# Altium schematic sheet 01_power.SchDoc(01_power)
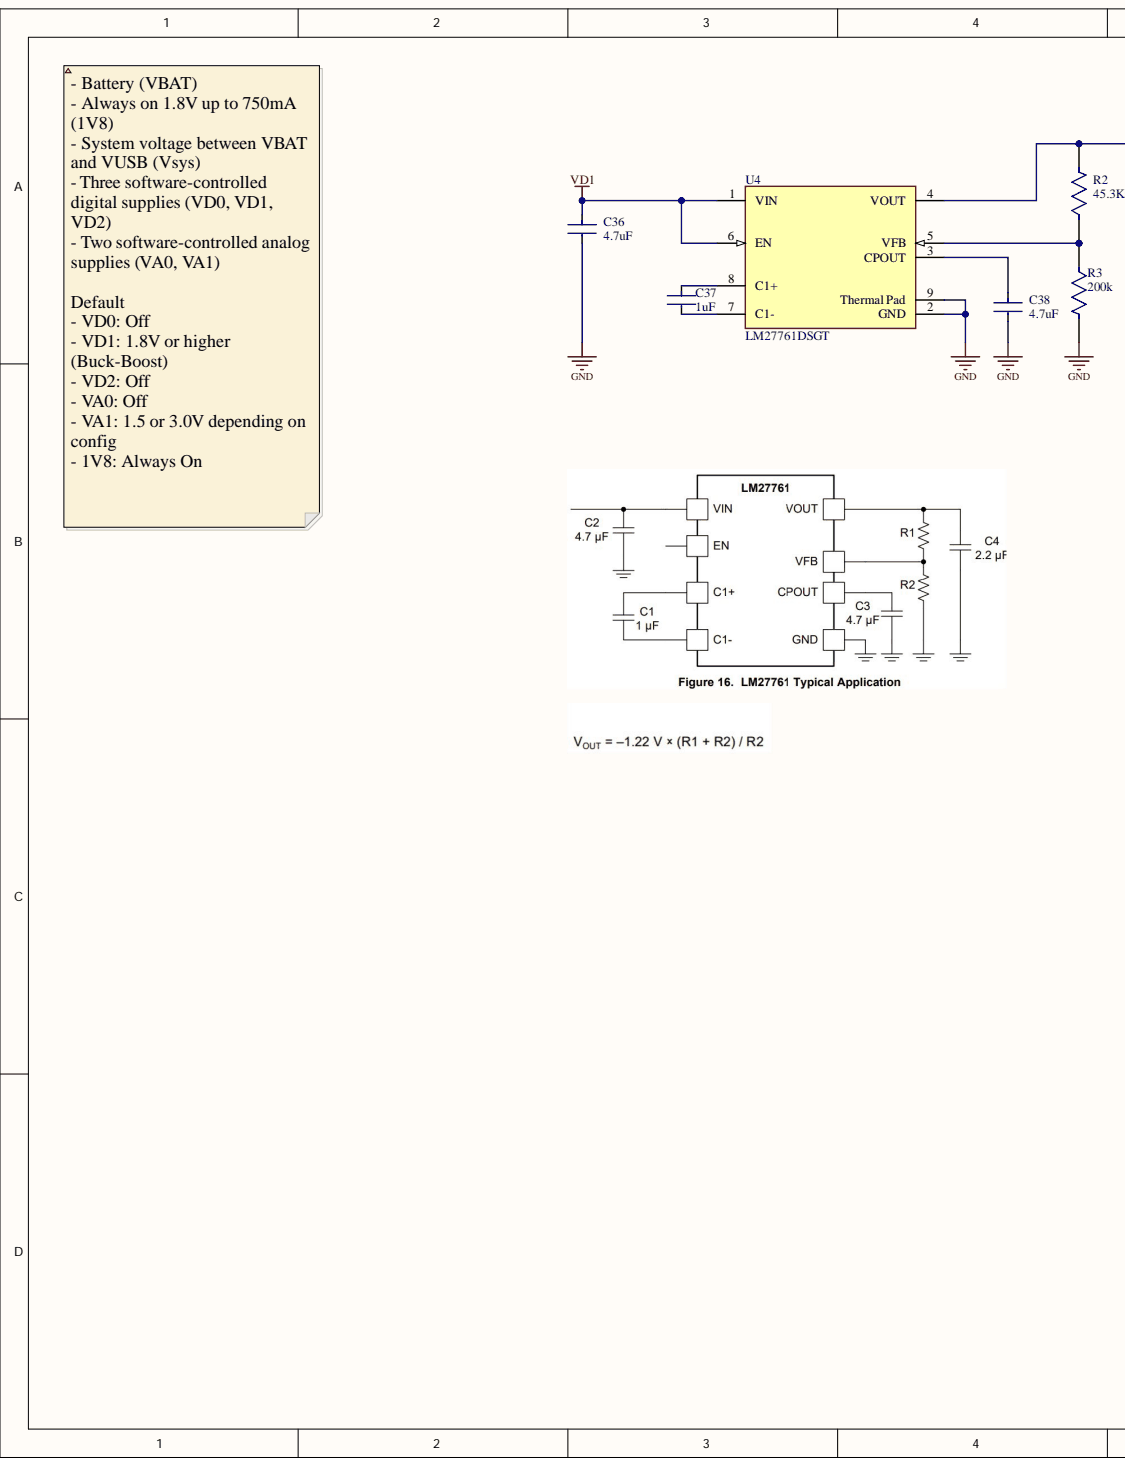
[diagram p0: 01_power.SchDoc, left]
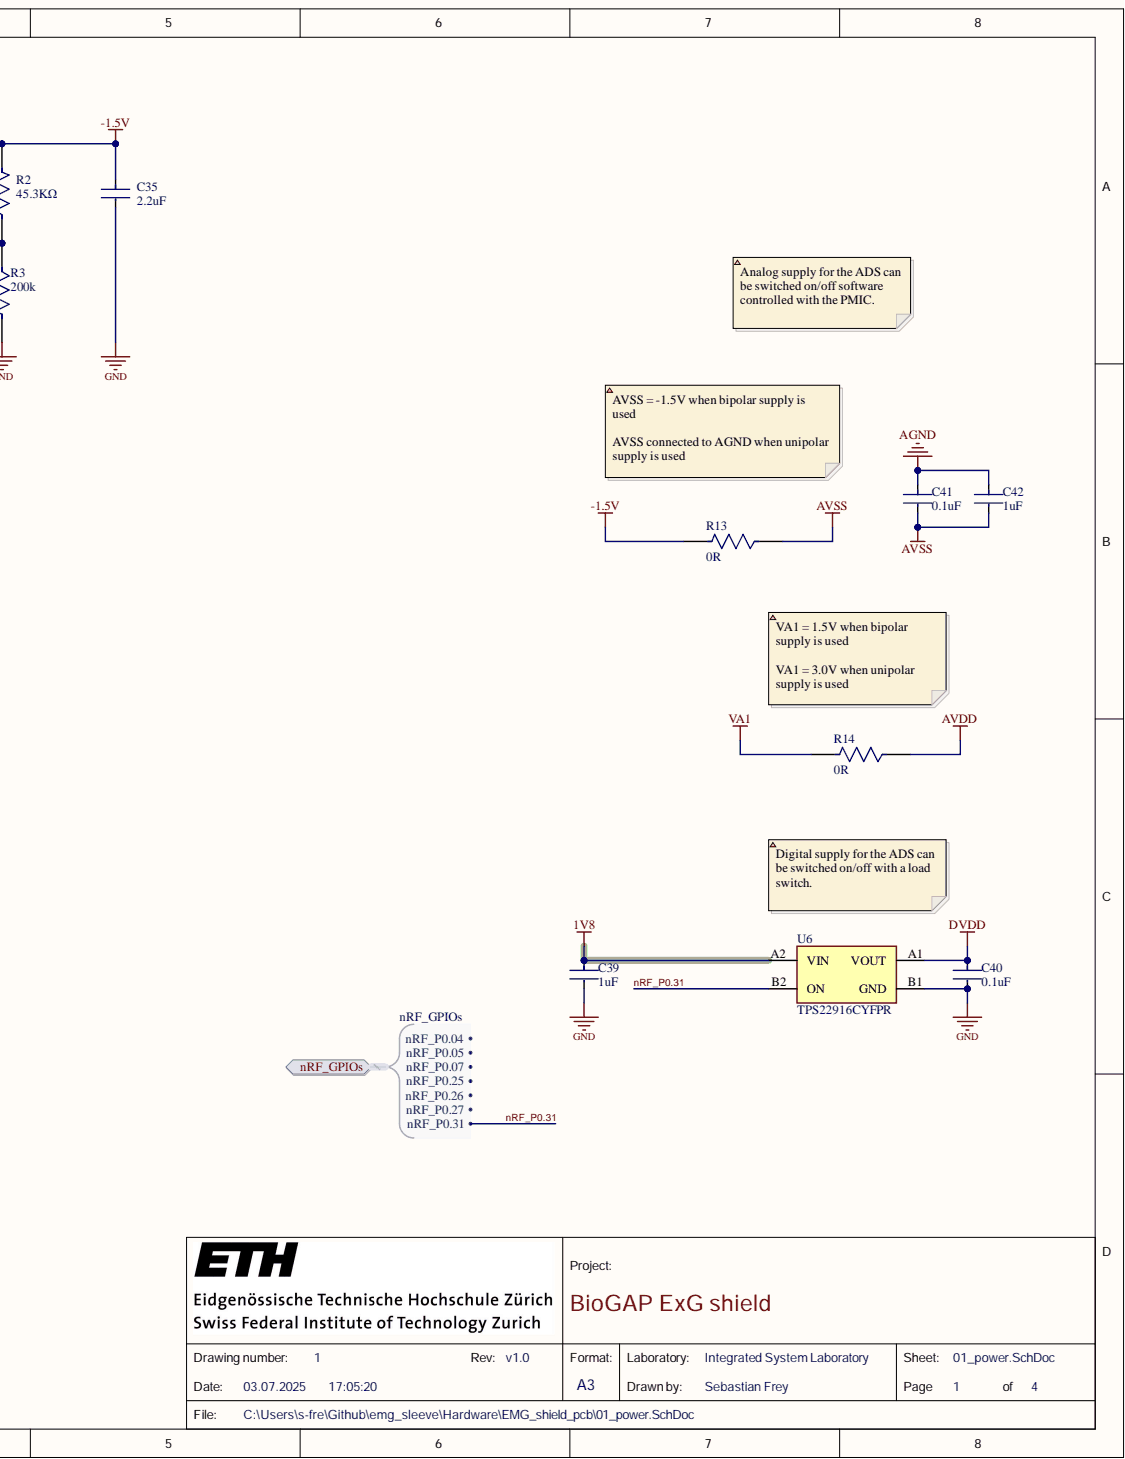
[diagram p1: 01_power.SchDoc, right]

## Parts
C35  value 2.2uF  Capacitance: 2.2uF  [FP-GRM155-0_15-IPC_B]  (hidden comment: GRM155Z71A225KE01D)
C36  value 4.7uF  Capacitance: 4.7uF  [FP-CL282-IPC_C]  (hidden comment: CL05A475MP5NRNC)
C37  value 1uF  Capacitance: 1uF  [FP-CL511-IPC_C]  (hidden comment: CL05A105KP5NNNC)
C38  value 4.7uF  Capacitance: 4.7uF  [FP-CL282-IPC_C]  (hidden comment: CL05A475MP5NRNC)
C39  value 1uF  Capacitance: 1uF  [FP-CL511-IPC_C]  (hidden comment: CL05A105KP5NNNC)
C40  value 0.1uF  Capacitance: 0.1uF  [FP-CL278-IPC_C]  (hidden comment: CL05A104KA5NNNC)
C41  value 0.1uF  Capacitance: 0.1uF  [FP-CL278-IPC_C]  (hidden comment: CL05A104KA5NNNC)
C42  value 1uF  Capacitance: 1uF  [FP-CL511-IPC_C]  (hidden comment: CL05A105KP5NNNC)
R2  value 45.3KΩ  Resistance: 45.3KΩ  [FP-RT0402-MFG]  (hidden comment: RT0402BRD0745K3L)
R3  value 200k  Resistance: 200k  [FP-RN73R1E-IPC_C]  (hidden comment: RN73R1ETTP2003B25)
R13  value 0R  Resistance: 0R  [FP-RC0402-0_4-IPC_A]  (hidden comment: RC0402FR-070RL)
R14  value 0R  Resistance: 0R  [FP-RC0402-0_4-IPC_A]  (hidden comment: RC0402FR-070RL)
U4  LM27761DSGT  [FP-DSG0008A-MFG]
U6  TPS22916CYFPR  [BGA4C40P2X2_78X78X50]

## Ports
nRF_GPIOs, nRF_GPIOs.nRF_P0.04, nRF_GPIOs.nRF_P0.05, nRF_GPIOs.nRF_P0.07, nRF_GPIOs.nRF_P0.25, nRF_GPIOs.nRF_P0.26, nRF_GPIOs.nRF_P0.27, nRF_GPIOs.nRF_P0.31

## Nets
1V8: C39.1 U6.A2(VIN)
-1.5V: C35.1 R2.2 R13.2 U4.4(VOUT)
AGND: C41.1 C42.1
AVDD: R14.1
AVSS: C41.2 C42.2 R13.1
DVDD: C40.1 U6.A1(VOUT)
GND: C35.2 C36.2 C38.2 C39.2 C40.2 R3.2 U4.2(GND) U4.9(Thermal_Pad) U6.B1(GND)
VA1: R14.2
VD1: C36.1 U4.1(VIN) U4.6(EN)
nRF_P0.31: U6.B2(ON) ; port nRF_GPIOs
NetC37_1: C37.1 U4.8(C1+)
NetC37_2: C37.2 U4.7(C1-)
NetC38_1: C38.1 U4.3(CPOUT)
NetR2_1: R2.1 R3.1 U4.5(VFB)
N00001: ; port nRF_GPIOs
N00002: ; port nRF_GPIOs
N00003: ; port nRF_GPIOs
N00004: ; port nRF_GPIOs
N00005: ; port nRF_GPIOs
N00006: ; port nRF_GPIOs

## Library data of the parts
C35 = CMP-06035-048202-1: Chip Multilayer Ceramic Capacitors for General Purpose, 0402, 2.2uF, X7R, 15%, 10%, 10V; Component Kind: Standard; Library Name: Altium Content Vault; Simulation: C_Capacitor_Default
C36 C38 = CMP-13271-000548-1: Cap Ceramic 4.7uF 10V X5R ±20% SMD 0402 +85°C Paper T/R; Case Code (Imperial): 0402; Case Code (Metric): 1005; Case/Package: 0402; Component Kind: Standard; Dielectric: X5R; Lead Free: Lead Free; Length: 0.039inch; Library Name: Altium Content Vault; Max Operating Temperature: 85°C; Min Operating Temperature: -55°C; Mount: Surface Mount; Package Quantity: 10000; Packaging: Tape and Reel; Simulation: C_Capacitor_Default; Thickness: 0.022inch; Tolerance: 20%; Voltage Rating: 10V; Width: 0.02inch
C37 C39 C42 = CMP-13271-001936-1: Cap Ceramic 1uF 10V X5R ±10% SMD 0402 +85°C Paper T/R; Case Code (Imperial): 0402; Case Code (Metric): 1005; Case/Package: 0402; Component Kind: Standard; Contact Plating: Tin; Depth: 0.5mm; Dielectric: X5R; Height: 0.5mm; Length: 1mm; Library Name: Altium Content Vault; Material: Ceramic; Max Operating Temperature: 85°C; Min Operating Temperature: -55°C; Mount: Surface Mount; Package Quantity: 1; Packaging: Tape and Reel; Radiation Hardening: No; Simulation: C_Capacitor_Default; Thickness: 0.022inch; Tolerance: 10%; Voltage Rating: 10V; Width: 0.02inch
C40 C41 = CMP-13271-000728-1: Cap Ceramic 100nF 25V X5R ±10% SMD 0402 +85°C Paper T/R; Case Code (Imperial): 0402; Case Code (Metric): 1005; Case/Package: 0402; Component Kind: Standard; Depth: 0.5mm; Dielectric: X5R; Height: 0.5mm; Lead Free: Lead Free; Length: 1mm; Library Name: Altium Content Vault; Max Operating Temperature: 85°C; Min Operating Temperature: -55°C; Mount: Surface Mount; Package Quantity: 1; Packaging: Tape and Reel; Radiation Hardening: No; Simulation: C_Capacitor_Default; Thickness: 0.022inch; Tolerance: 10%; Voltage: 25V; Voltage Rating: 25V; Voltage Rating (DC): 25V; Width: 0.02inch
R2 = CMP-03412-018483-1: RES 45.3K OHM 1/16W .1% SMD 0402; Manufacturer: Yageo; Power Rating: 0.063W; Temperature Coefficient: 25 ppm/°C; Tolerance: 0.001; Voltage Rating: 50V; Automotive: No; Category: Res; Component Kind: Standard; ComponentLink2Description: Datasheet; ComponentLink2URL: http://www.yageo.com/documents/recent/PYu-RT_1-to-0.01_RoHS_L_9.pdf; Composition: Thin Film; Device Class L1: Passive Components; Device Class L2: Resistors; Device Class L3: Chip SMD Resistors; Digikey Description: RES 45.3K OHM 1/16W .1% SMD 0402; Footprint Url: http://www.yageo.com/exep/pages/download/literatures/PYu-R_Mount_10.pdf; Height: 0.35mm; Ipc Land Pattern Name: RESC10050X30; Lead Free: Yes; Library Name: Altium Content Vault; Manufacturer Part Number: RT0402BRD0745K3L; Mouser Description: Thin Film Resistors 1/16W 45.3K ohm .1% 25ppm; Octopart: https://octopart.com/rt0402brd0745k3l-yageo-1472491; Package: RT0402; RoHS: TRUE; Series: RT; Simulation: R_Resistor_Default; Temperature: -55°C to +155°C; Temperature Range High: +155°C; Temperature Range Low: -55°C
R3 = CMP-04015-050624-1: 200kΩ ±0.1% 0.063W, 1/16W Chip Resistor 0402 (1005 Metric) Moisture Resistant Thin Film; Component Kind: Standard; Library Name: Altium Content Vault; Simulation: R_Resistor_Default
R13 R14 = CMP-2002-07342-2: RES SMD 0 OHM JUMPER 1/16W 0402; Max Power Dissipation: 63mW; Power Rating: 62.5mW; Temperature Coefficient: 200ppm/°C; Tolerance: 1%; Voltage Rating: 50V; Case Code (Imperial): 0402; Case Code (Metric): 1005; Case/Package: 0402; Component Kind: Standard; Contact Plating: Tin; Features: Moisture Resistant; Height: 0.35mm; Lead Free: Lead Free; Length: 1mm; Library Name: Altium Content Vault; Max Operating Temperature: 155°C; Min Operating Temperature: -55°C; Mount: Surface Mount; Number of Terminations: 2; Packaging: Tape and Reel; Radiation Hardening: No; Resistor Type: Thick Film; RoHS Compliant: Yes; Series: RC; Simulation: R_Resistor_Default; Size Code: 0402; Termination: SMD/SMT; Width: 0.5mm
U4 = CMP-04918-000089-1: IC REG CHARGE PUMP ADJ 8WSON; Manufacturer: Texas Instruments; Max Junction Temp: +125°C; Max Supply Voltage: 5.5V; Min Supply Voltage: 2.7V; Negative Voltage Available: true; Nominal Supply Current: 370uA; Number Of Outputs: 1; Output Current: 250mA; Output Type: AdjustableProgrammable; Output Voltage: -5-1.5V; Standoff Height: 0mm; Switching Frequency: 2MHz; Ambient Temperature Range High: +85°C; Ambient Temperature Range Low: -40°C; Automotive: No; Category: IC; Component Kind: Standard; ComponentLink2Description: Datasheet; ComponentLink2URL: http://www.ti.com/lit/ds/symlink/lm27761.pdf; Device Class L1: Integrated Circuits (ICs); Device Class L2: Power Management ICs; Device Class L3: Voltage Regulators - Switching; Digikey Description: IC REG CHARGE PUMP ADJ 8WSON; Height: 0.8mm; Lead Free: Yes; Library Name: Altium Content Vault; Manufacturer Part Number: LM27761DSGT; Mouser Description: Switching Voltage Regulators Low-Noise Regulated Switched Capacitor; Octopart: https://octopart.com/lm27761dsgt-texas+instruments-66646294; Package: DSG0008A; RoHS: TRUE; Switching Topology: Charge Pump; Temperature: -40°C to +85°C; Temperature Range High: +85°C; Temperature Range Low: -40°C
U6 = TPS22916CYFPR: Integrated Circuit; Manufacturer_Name: Texas Instruments; Arrow Part Number: TPS22916CYFPR; Arrow Price/Stock: https://www.arrow.com/en/products/tps22916cyfpr/texas-instruments?region=nac; Component Kind: Standard; Datasheet Link: http://www.ti.com/lit/gpn/tps22916; Height: 0.5mm; Library Name: SamacSys.SchLib; Manufacturer_Part_Number: TPS22916CYFPR; Mouser Part Number: 595-TPS22916CYFPR; Mouser Price/Stock: https://www.mouser.co.uk/ProductDetail/Texas-Instruments/TPS22916CYFPR?qs=EU6FO9ffTweddKR4NsfVQg%3D%3D
